# Altium schematic sheet FPGA_BANK.SchDoc
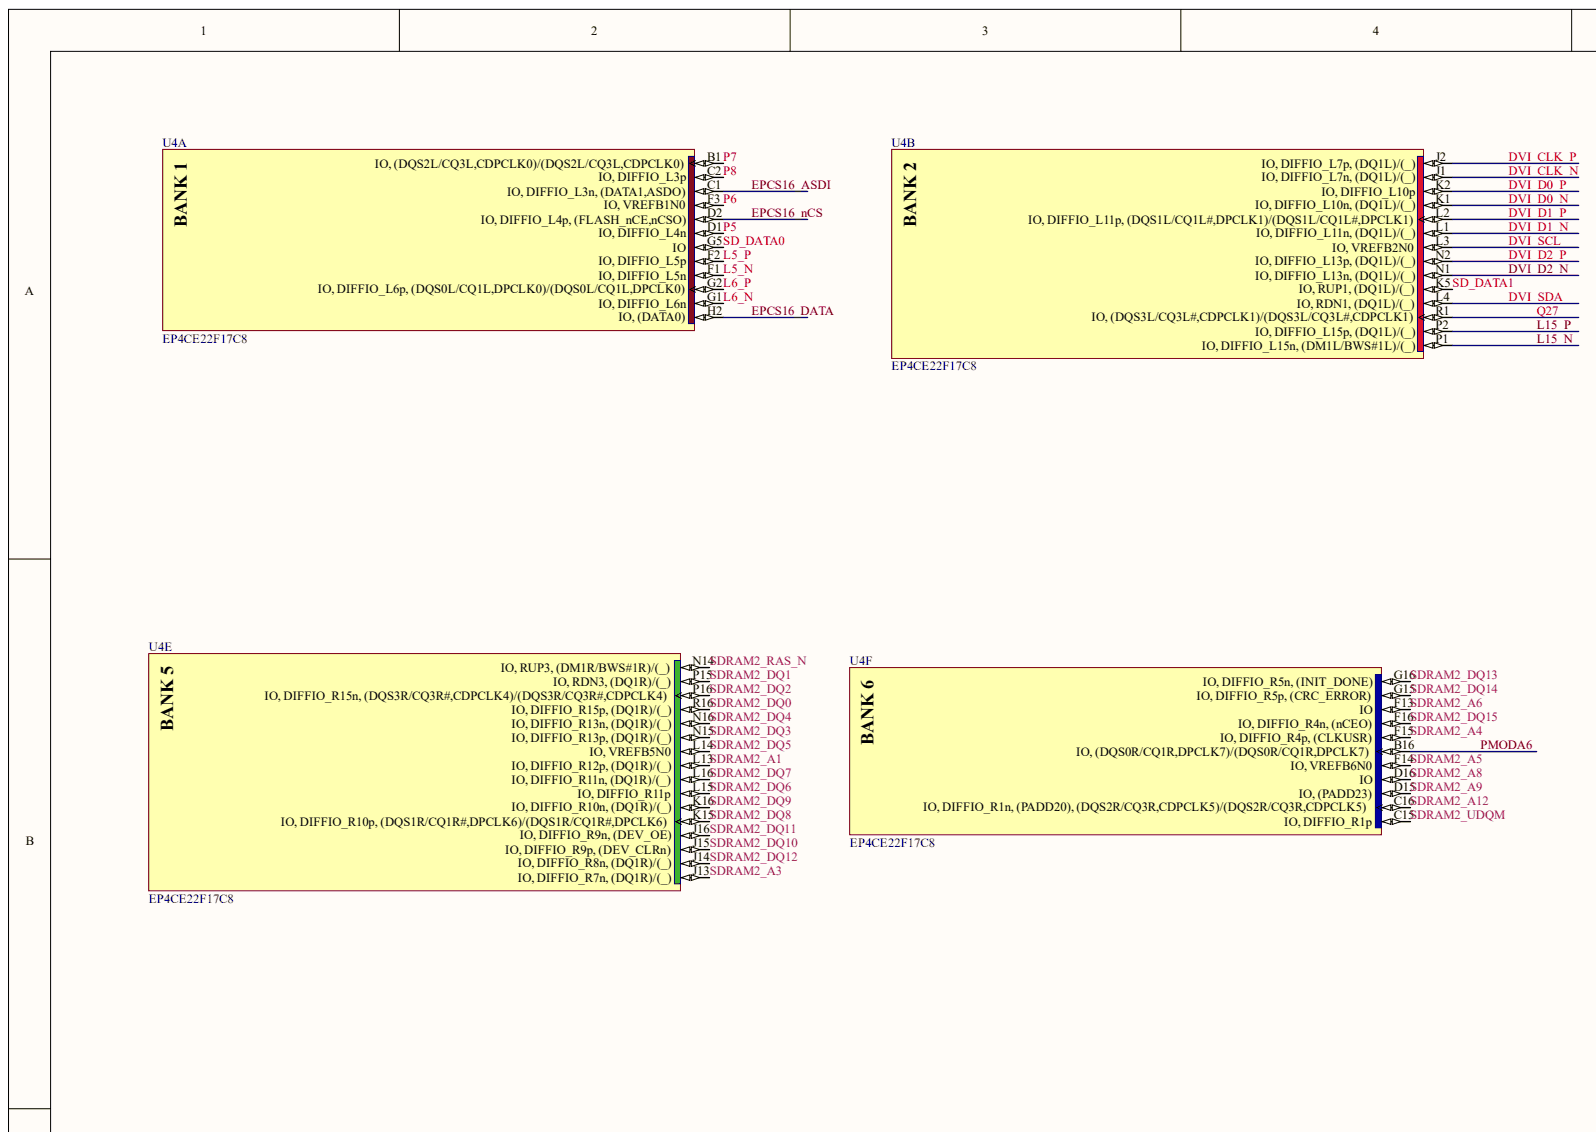
[diagram p0: FPGA_BANK.SchDoc, top left]
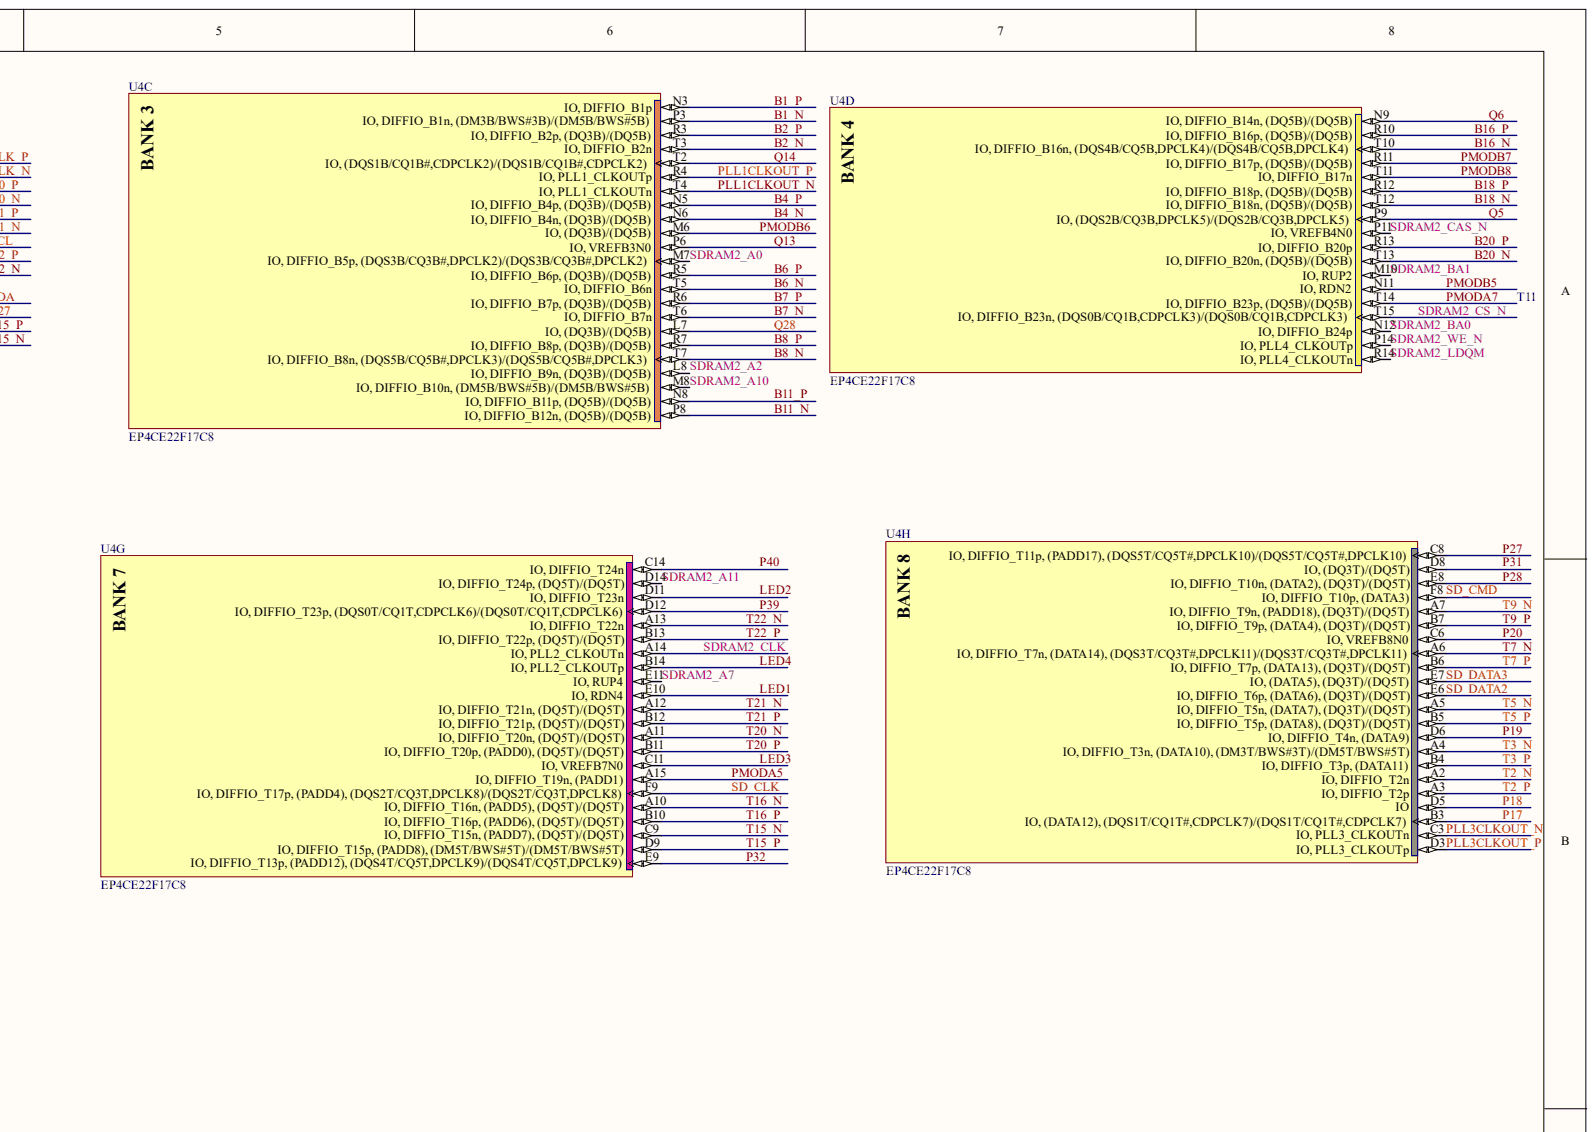
[diagram p1: FPGA_BANK.SchDoc, top right]
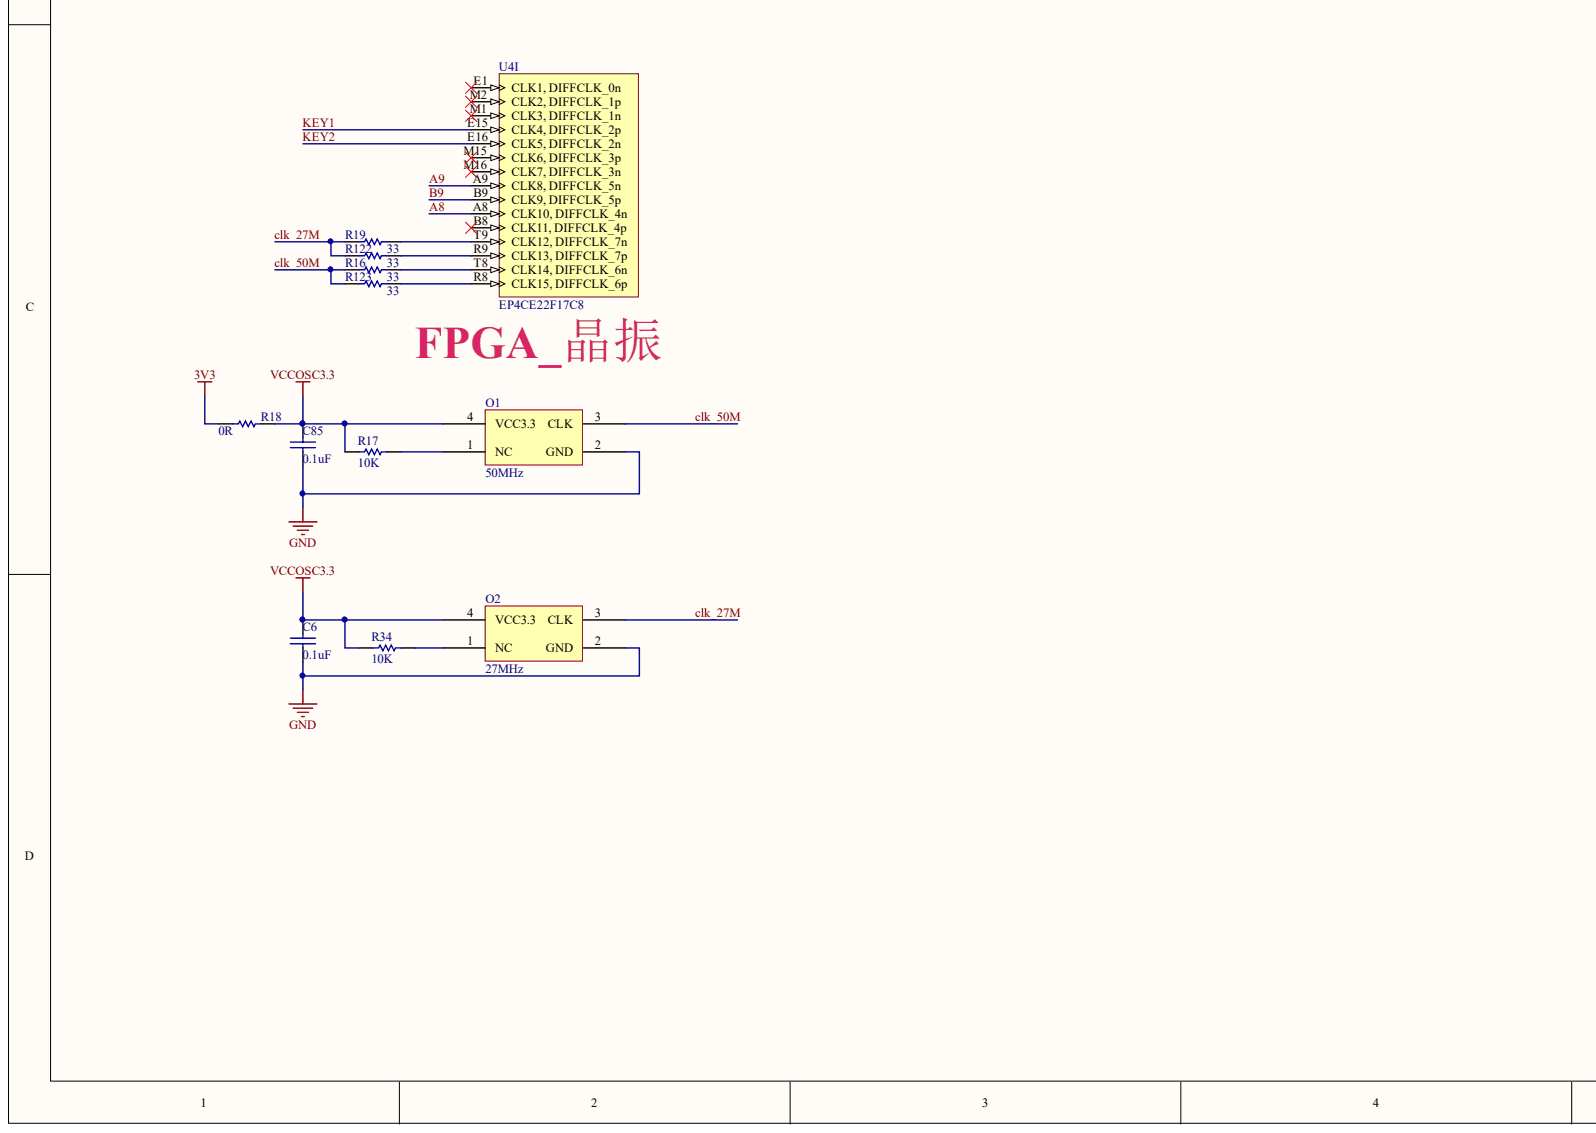
[diagram p2: FPGA_BANK.SchDoc, bottom left]
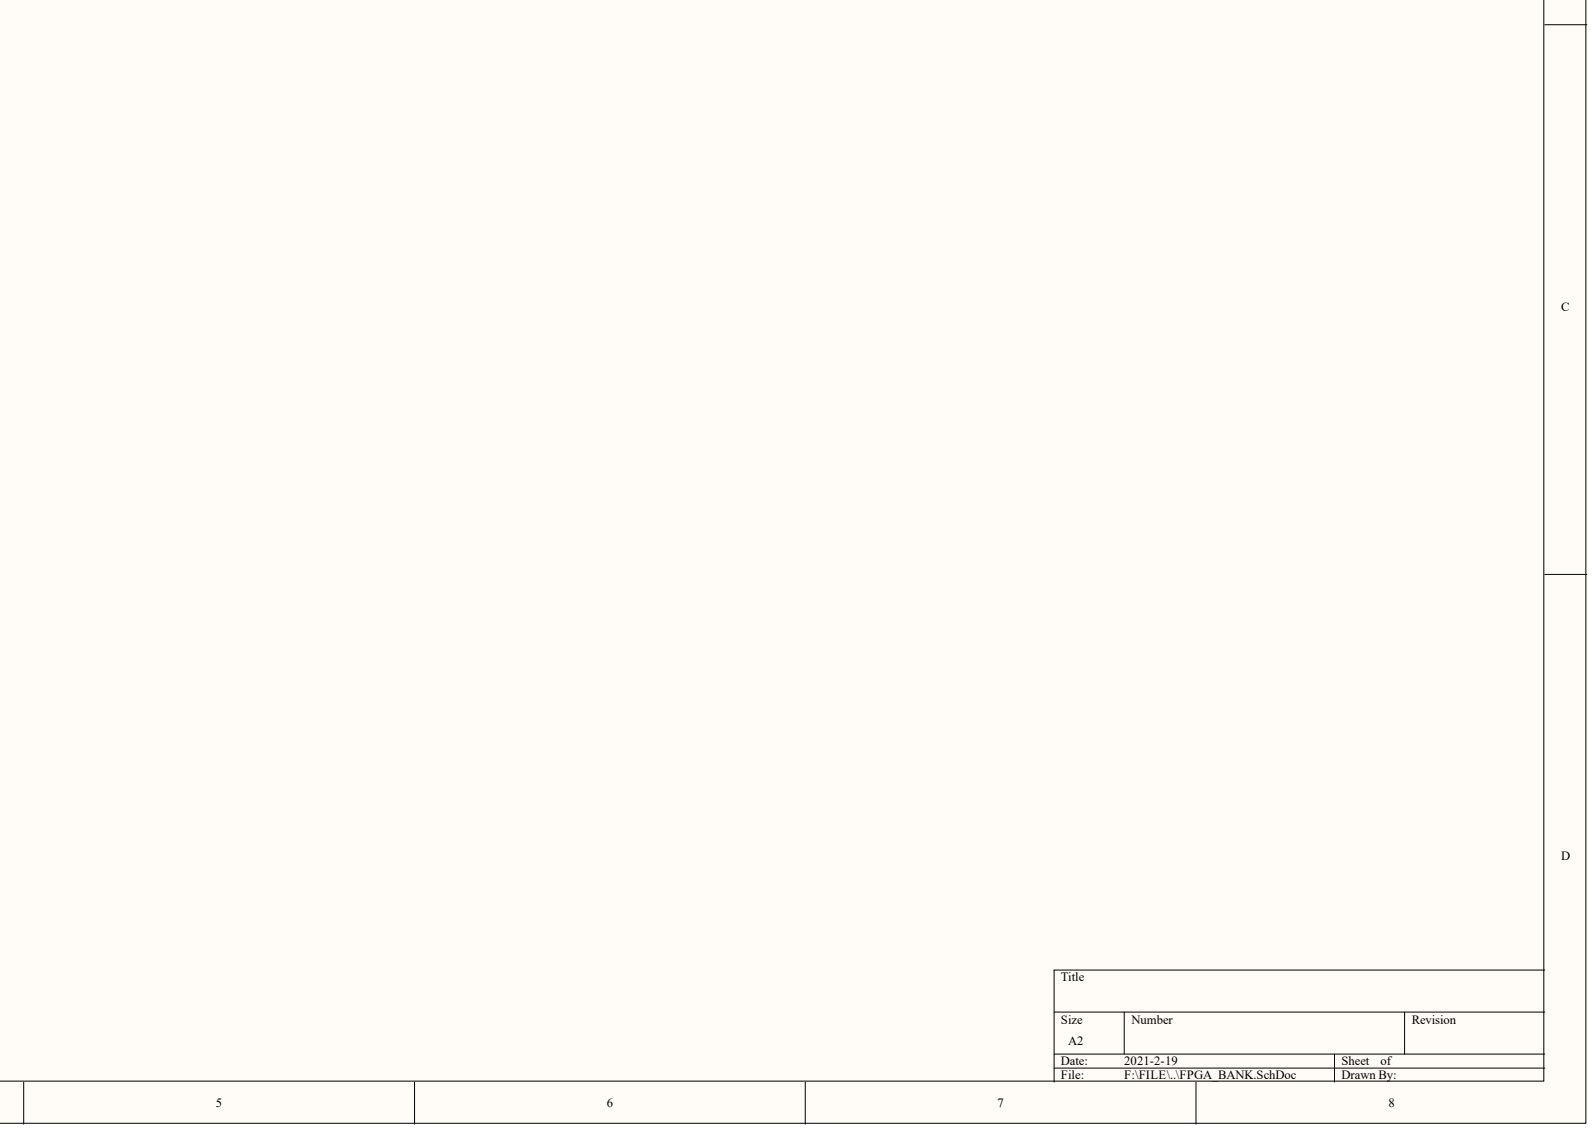
[diagram p3: FPGA_BANK.SchDoc, bottom right]

## Parts
C6  0.1uF  [C0402]
C85  0.1uF  [C0402]
O1  50MHz  [SMD-3225_4P]
O2  27MHz  [SMD-3225_4P]
R16  33  [R_0402]
R17  10K  [R0402]
R18  0R  [0603_Q0603A]
R19  33  [R_0402]
R34  10K  [R0402]
R122  33  [R_0402]
R123  33  [R_0402]
U4  EP4CE22F17C8  [FBGA256]  (parts A,B,C,D,E,F,G,H,I)

## Nets
3V3: R18.2
GND: C6.2 C85.2 O1.2(GND) O2.2(GND)
VCCOSC3.3: C6.1 C85.1 O1.4(VCC3.3) O2.4(VCC3.3) R17.2 R18.1 R34.2
A8: U4.A8(CLK10,_DIFFCLK_4n)
A9: U4.A9(CLK8,_DIFFCLK_5n)
B1_N: U4.P3(IO,_DIFFIO_B1n,_(DM3B/BWS#3B)/(DM5B/BWS#5B))
B1_P: U4.N3(IO,_DIFFIO_B1p)
B2_N: U4.T3(IO,_DIFFIO_B2n)
B2_P: U4.R3(IO,_DIFFIO_B2p,_(DQ3B)/(DQ5B))
B4_N: U4.N6(IO,_DIFFIO_B4n,_(DQ3B)/(DQ5B))
B4_P: U4.N5(IO,_DIFFIO_B4p,_(DQ3B)/(DQ5B))
B6_N: U4.T5(IO,_DIFFIO_B6n)
B6_P: U4.R5(IO,_DIFFIO_B6p,_(DQ3B)/(DQ5B))
B7_N: U4.T6(IO,_DIFFIO_B7n)
B7_P: U4.R6(IO,_DIFFIO_B7p,_(DQ3B)/(DQ5B))
B8_N: U4.T7(IO,_DIFFIO_B8n,_(DQS5B/CQ5B#,DPCLK3)/(DQS5B/CQ5B#,DPCLK3))
B8_P: U4.R7(IO,_DIFFIO_B8p,_(DQ3B)/(DQ5B))
B9: U4.B9(CLK9,_DIFFCLK_5p)
B11_N: U4.P8(IO,_DIFFIO_B12n,_(DQ5B)/(DQ5B))
B11_P: U4.N8(IO,_DIFFIO_B11p,_(DQ5B)/(DQ5B))
B16_N: U4.T10(IO,_DIFFIO_B16n,_(DQS4B/CQ5B,DPCLK4)/(DQS4B/CQ5B,DPCLK4))
B16_P: U4.R10(IO,_DIFFIO_B16p,_(DQ5B)/(DQ5B))
B18_N: U4.T12(IO,_DIFFIO_B18n,_(DQ5B)/(DQ5B))
B18_P: U4.R12(IO,_DIFFIO_B18p,_(DQ5B)/(DQ5B))
B20_N: U4.T13(IO,_DIFFIO_B20n,_(DQ5B)/(DQ5B))
B20_P: U4.R13(IO,_DIFFIO_B20p)
CLK_27M: O2.3(CLK) R19.1 R122.1
CLK_50M: O1.3(CLK) R16.1 R123.1
DVI_CLK_N: U4.J1(IO,_DIFFIO_L7n,_(DQ1L)/(_))
DVI_CLK_P: U4.J2(IO,_DIFFIO_L7p,_(DQ1L)/(_))
DVI_D0_N: U4.K1(IO,_DIFFIO_L10n,_(DQ1L)/(_))
DVI_D0_P: U4.K2(IO,_DIFFIO_L10p)
DVI_D1_N: U4.L1(IO,_DIFFIO_L11n,_(DQ1L)/(_))
DVI_D1_P: U4.L2(IO,_DIFFIO_L11p,_(DQS1L/CQ1L#,DPCLK1)/(DQS1L/CQ1L#,DPCLK1))
DVI_D2_N: U4.N1(IO,_DIFFIO_L13n,_(DQ1L)/(_))
DVI_D2_P: U4.N2(IO,_DIFFIO_L13p,_(DQ1L)/(_))
DVI_SCL: U4.L3(IO,_VREFB2N0)
DVI_SDA: U4.L4(IO,_RDN1,_(DQ1L)/(_))
EPCS16_ASDI: U4.C1(IO,_DIFFIO_L3n,_(DATA1,ASDO))
EPCS16_DATA: U4.H2(IO,_(DATA0))
EPCS16_NCS: U4.D2(IO,_DIFFIO_L4p,_(FLASH_nCE,nCSO))
KEY1: U4.E15(CLK4,_DIFFCLK_2p)
KEY2: U4.E16(CLK5,_DIFFCLK_2n)
L5_N: U4.F1(IO,_DIFFIO_L5n)
L5_P: U4.F2(IO,_DIFFIO_L5p)
L6_N: U4.G1(IO,_DIFFIO_L6n)
L6_P: U4.G2(IO,_DIFFIO_L6p,_(DQS0L/CQ1L,DPCLK0)/(DQS0L/CQ1L,DPCLK0))
L15_N: U4.P1(IO,_DIFFIO_L15n,_(DM1L/BWS#1L)/(_))
L15_P: U4.P2(IO,_DIFFIO_L15p,_(DQ1L)/(_))
LED1: U4.E10(IO,_RDN4)
LED2: U4.D11(IO,_DIFFIO_T23n)
LED3: U4.C11(IO,_VREFB7N0)
LED4: U4.B14(IO,_PLL2_CLKOUTp)
P5: U4.D1(IO,_DIFFIO_L4n)
P6: U4.F3(IO,_VREFB1N0)
P7: U4.B1(IO,_(DQS2L/CQ3L,CDPCLK0)/(DQS2L/CQ3L,CDPCLK0))
P8: U4.C2(IO,_DIFFIO_L3p)
P17: U4.B3(IO,_(DATA12),_(DQS1T/CQ1T#,CDPCLK7)/(DQS1T/CQ1T#,CDPCLK7))
P18: U4.D5(IO)
P19: U4.D6(IO,_DIFFIO_T4n,_(DATA9))
P20: U4.C6(IO,_VREFB8N0)
P27: U4.C8(IO,_DIFFIO_T11p,_(PADD17),_(DQS5T/CQ5T#,DPCLK10)/(DQS5T/CQ5T#,DPCLK10))
P28: U4.E8(IO,_DIFFIO_T10n,_(DATA2),_(DQ3T)/(DQ5T))
P31: U4.D8(IO,_(DQ3T)/(DQ5T))
P32: U4.E9(IO,_DIFFIO_T13p,_(PADD12),_(DQS4T/CQ5T,DPCLK9)/(DQS4T/CQ5T,DPCLK9))
P39: U4.D12(IO,_DIFFIO_T23p,_(DQS0T/CQ1T,CDPCLK6)/(DQS0T/CQ1T,CDPCLK6))
P40: U4.C14(IO,_DIFFIO_T24n)
PLL1CLKOUT_N: U4.T4(IO,_PLL1_CLKOUTn)
PLL1CLKOUT_P: U4.R4(IO,_PLL1_CLKOUTp)
PLL3CLKOUT_N: U4.C3(IO,_PLL3_CLKOUTn)
PLL3CLKOUT_P: U4.D3(IO,_PLL3_CLKOUTp)
PMODA5: U4.A15(IO,_DIFFIO_T19n,_(PADD1))
PMODA6: U4.B16(IO,_(DQS0R/CQ1R,DPCLK7)/(DQS0R/CQ1R,DPCLK7))
PMODA7: U4.T14(IO,_DIFFIO_B23p,_(DQ5B)/(DQ5B))
PMODB5: U4.N11(IO,_RDN2)
PMODB6: U4.M6(IO,_(DQ3B)/(DQ5B))
PMODB7: U4.R11(IO,_DIFFIO_B17p,_(DQ5B)/(DQ5B))
PMODB8: U4.T11(IO,_DIFFIO_B17n)
Q5: U4.P9(IO,_(DQS2B/CQ3B,DPCLK5)/(DQS2B/CQ3B,DPCLK5))
Q6: U4.N9(IO,_DIFFIO_B14n,_(DQ5B)/(DQ5B))
Q13: U4.P6(IO,_VREFB3N0)
Q14: U4.T2(IO,_(DQS1B/CQ1B#,CDPCLK2)/(DQS1B/CQ1B#,CDPCLK2))
Q27: U4.R1(IO,_(DQS3L/CQ3L#,CDPCLK1)/(DQS3L/CQ3L#,CDPCLK1))
Q28: U4.L7(IO,_(DQ3B)/(DQ5B))
SD_CLK: U4.F9(IO,_DIFFIO_T17p,_(PADD4),_(DQS2T/CQ3T,DPCLK8)/(DQS2T/CQ3T,DPCLK8))
SD_CMD: U4.F8(IO,_DIFFIO_T10p,_(DATA3))
SD_DATA0: U4.G5(IO)
SD_DATA1: U4.K5(IO,_RUP1,_(DQ1L)/(_))
SD_DATA2: U4.E6(IO,_DIFFIO_T6p,_(DATA6),_(DQ3T)/(DQ5T))
SD_DATA3: U4.E7(IO,_(DATA5),_(DQ3T)/(DQ5T))
SDRAM2_A0: U4.M7(IO,_DIFFIO_B5p,_(DQS3B/CQ3B#,DPCLK2)/(DQS3B/CQ3B#,DPCLK2))
SDRAM2_A1: U4.L13(IO,_DIFFIO_R12p,_(DQ1R)/(_))
SDRAM2_A2: U4.L8(IO,_DIFFIO_B9n,_(DQ3B)/(DQ5B))
SDRAM2_A3: U4.J13(IO,_DIFFIO_R7n,_(DQ1R)/(_))
SDRAM2_A4: U4.F15(IO,_DIFFIO_R4p,_(CLKUSR))
SDRAM2_A5: U4.F14(IO,_VREFB6N0)
SDRAM2_A6: U4.F13(IO)
SDRAM2_A7: U4.E11(IO,_RUP4)
SDRAM2_A8: U4.D16(IO)
SDRAM2_A9: U4.D15(IO,_(PADD23))
SDRAM2_A10: U4.M8(IO,_DIFFIO_B10n,_(DM5B/BWS#5B)/(DM5B/BWS#5B))
SDRAM2_A11: U4.D14(IO,_DIFFIO_T24p,_(DQ5T)/(DQ5T))
SDRAM2_A12: U4.C16(IO,_DIFFIO_R1n,_(PADD20),_(DQS2R/CQ3R,CDPCLK5)/(DQS2R/CQ3R,CDPCLK5))
SDRAM2_BA0: U4.N12(IO,_DIFFIO_B24p)
SDRAM2_BA1: U4.M10(IO,_RUP2)
SDRAM2_CAS_N: U4.P11(IO,_VREFB4N0)
SDRAM2_CLK: U4.A14(IO,_PLL2_CLKOUTn)
SDRAM2_CS_N: U4.T15(IO,_DIFFIO_B23n,_(DQS0B/CQ1B,CDPCLK3)/(DQS0B/CQ1B,CDPCLK3))
SDRAM2_DQ0: U4.R16(IO,_DIFFIO_R15p,_(DQ1R)/(_))
SDRAM2_DQ1: U4.P15(IO,_RDN3,_(DQ1R)/(_))
SDRAM2_DQ2: U4.P16(IO,_DIFFIO_R15n,_(DQS3R/CQ3R#,CDPCLK4)/(DQS3R/CQ3R#,CDPCLK4))
SDRAM2_DQ3: U4.N15(IO,_DIFFIO_R13p,_(DQ1R)/(_))
SDRAM2_DQ4: U4.N16(IO,_DIFFIO_R13n,_(DQ1R)/(_))
SDRAM2_DQ5: U4.L14(IO,_VREFB5N0)
SDRAM2_DQ6: U4.L15(IO,_DIFFIO_R11p)
SDRAM2_DQ7: U4.L16(IO,_DIFFIO_R11n,_(DQ1R)/(_))
SDRAM2_DQ8: U4.K15(IO,_DIFFIO_R10p,_(DQS1R/CQ1R#,DPCLK6)/(DQS1R/CQ1R#,DPCLK6))
SDRAM2_DQ9: U4.K16(IO,_DIFFIO_R10n,_(DQ1R)/(_))
SDRAM2_DQ10: U4.J15(IO,_DIFFIO_R9p,_(DEV_CLRn))
SDRAM2_DQ11: U4.J16(IO,_DIFFIO_R9n,_(DEV_OE))
SDRAM2_DQ12: U4.J14(IO,_DIFFIO_R8n,_(DQ1R)/(_))
SDRAM2_DQ13: U4.G16(IO,_DIFFIO_R5n,_(INIT_DONE))
SDRAM2_DQ14: U4.G15(IO,_DIFFIO_R5p,_(CRC_ERROR))
SDRAM2_DQ15: U4.F16(IO,_DIFFIO_R4n,_(nCEO))
SDRAM2_LDQM: U4.R14(IO,_PLL4_CLKOUTn)
SDRAM2_RAS_N: U4.N14(IO,_RUP3,_(DM1R/BWS#1R)/(_))
SDRAM2_UDQM: U4.C15(IO,_DIFFIO_R1p)
SDRAM2_WE_N: U4.P14(IO,_PLL4_CLKOUTp)
T2_N: U4.A2(IO,_DIFFIO_T2n)
T2_P: U4.A3(IO,_DIFFIO_T2p)
T3_N: U4.A4(IO,_DIFFIO_T3n,_(DATA10),_(DM3T/BWS#3T)/(DM5T/BWS#5T))
T3_P: U4.B4(IO,_DIFFIO_T3p,_(DATA11))
T5_N: U4.A5(IO,_DIFFIO_T5n,_(DATA7),_(DQ3T)/(DQ5T))
T5_P: U4.B5(IO,_DIFFIO_T5p,_(DATA8),_(DQ3T)/(DQ5T))
T7_N: U4.A6(IO,_DIFFIO_T7n,_(DATA14),_(DQS3T/CQ3T#,DPCLK11)/(DQS3T/CQ3T#,DPCLK11))
T7_P: U4.B6(IO,_DIFFIO_T7p,_(DATA13),_(DQ3T)/(DQ5T))
T9_N: U4.A7(IO,_DIFFIO_T9n,_(PADD18),_(DQ3T)/(DQ5T))
T9_P: U4.B7(IO,_DIFFIO_T9p,_(DATA4),_(DQ3T)/(DQ5T))
T15_N: U4.C9(IO,_DIFFIO_T15n,_(PADD7),_(DQ5T)/(DQ5T))
T15_P: U4.D9(IO,_DIFFIO_T15p,_(PADD8),_(DM5T/BWS#5T)/(DM5T/BWS#5T))
T16_N: U4.A10(IO,_DIFFIO_T16n,_(PADD5),_(DQ5T)/(DQ5T))
T16_P: U4.B10(IO,_DIFFIO_T16p,_(PADD6),_(DQ5T)/(DQ5T))
T20_N: U4.A11(IO,_DIFFIO_T20n,_(DQ5T)/(DQ5T))
T20_P: U4.B11(IO,_DIFFIO_T20p,_(PADD0),_(DQ5T)/(DQ5T))
T21_N: U4.A12(IO,_DIFFIO_T21n,_(DQ5T)/(DQ5T))
T21_P: U4.B12(IO,_DIFFIO_T21p,_(DQ5T)/(DQ5T))
T22_N: U4.A13(IO,_DIFFIO_T22n)
T22_P: U4.B13(IO,_DIFFIO_T22p,_(DQ5T)/(DQ5T))
NetO1_1: O1.1(NC) R17.1
NetO2_1: O2.1(NC) R34.1
NetR16_2: R16.2 U4.T8(CLK14,_DIFFCLK_6n)
NetR19_2: R19.2 U4.T9(CLK12,_DIFFCLK_7n)
NetR122_2: R122.2 U4.R9(CLK13,_DIFFCLK_7p)
NetR123_2: R123.2 U4.R8(CLK15,_DIFFCLK_6p)

Not connected: U4 B8(CLK11,_DIFFCLK_4p) E1(CLK1,_DIFFCLK_0n) M1(CLK3,_DIFFCLK_1n) M2(CLK2,_DIFFCLK_1p) M15(CLK6,_DIFFCLK_3p) M16(CLK7,_DIFFCLK_3n)

## Library data of the parts
C6 C85 = CAP: 无极性电容; Component Kind: Standard; Library Name: 电容.IntLib
O1 O2 = oscillator: Component Kind: Standard; Library Name: the_god_of_death.SchLib
R16-R19 R34 R122 R123 = RES: Component Kind: Standard
  R17 R18 R34: Library Name: 电阻.IntLib
  R16 R19 R122 R123: Library Name: the_god_of_death.IntLib
U4 = EP4CE22F17C8: Cyclone IV Family FPGA, 2V Core, 153 I/O Pins, 4 PLLs, 256-Pin FBGA, Speed Grade 8, Commercial Grade; Code_IPC: BGA100P16X16-256; Code_JEDEC: MS-034-AAF-1; Component Kind: Standard; ComponentLink1Description: Manufacturer Link; ComponentLink1URL: http://www.altera.com/; ComponentLink2Description: Handbook; ComponentLink2URL: http://www.altera.com/literature/hb/cyclone-iv/cyclone4-handbook.pdf; ComponentLink3Description: Pinout Specification; ComponentLink3URL: http://www.altera.com/literature/lit-dp.jsp?category=cyc%204&showspreadsheet=y; ComponentLink4Description: Packaging Specification; ComponentLink4URL: http://www.altera.com/literature/ds/dspkg.pdf; DatasheetVersion: ver1.2, Mar-2010; Library Name: Altera Cyclone IV E.SchLib; PackageDescription: 256-Ball FineLine Ball Grid Array (FBGA256) - Option 1 - Wire Bond; PackageReference: FBGA256; PackageVersion: ver16.0, Dec-2009; Published: 3-May-2010; Signal Integrity: EP4CE_F256; Publisher: Altium Limited
